# Altium schematic sheet wch554e.SchDoc
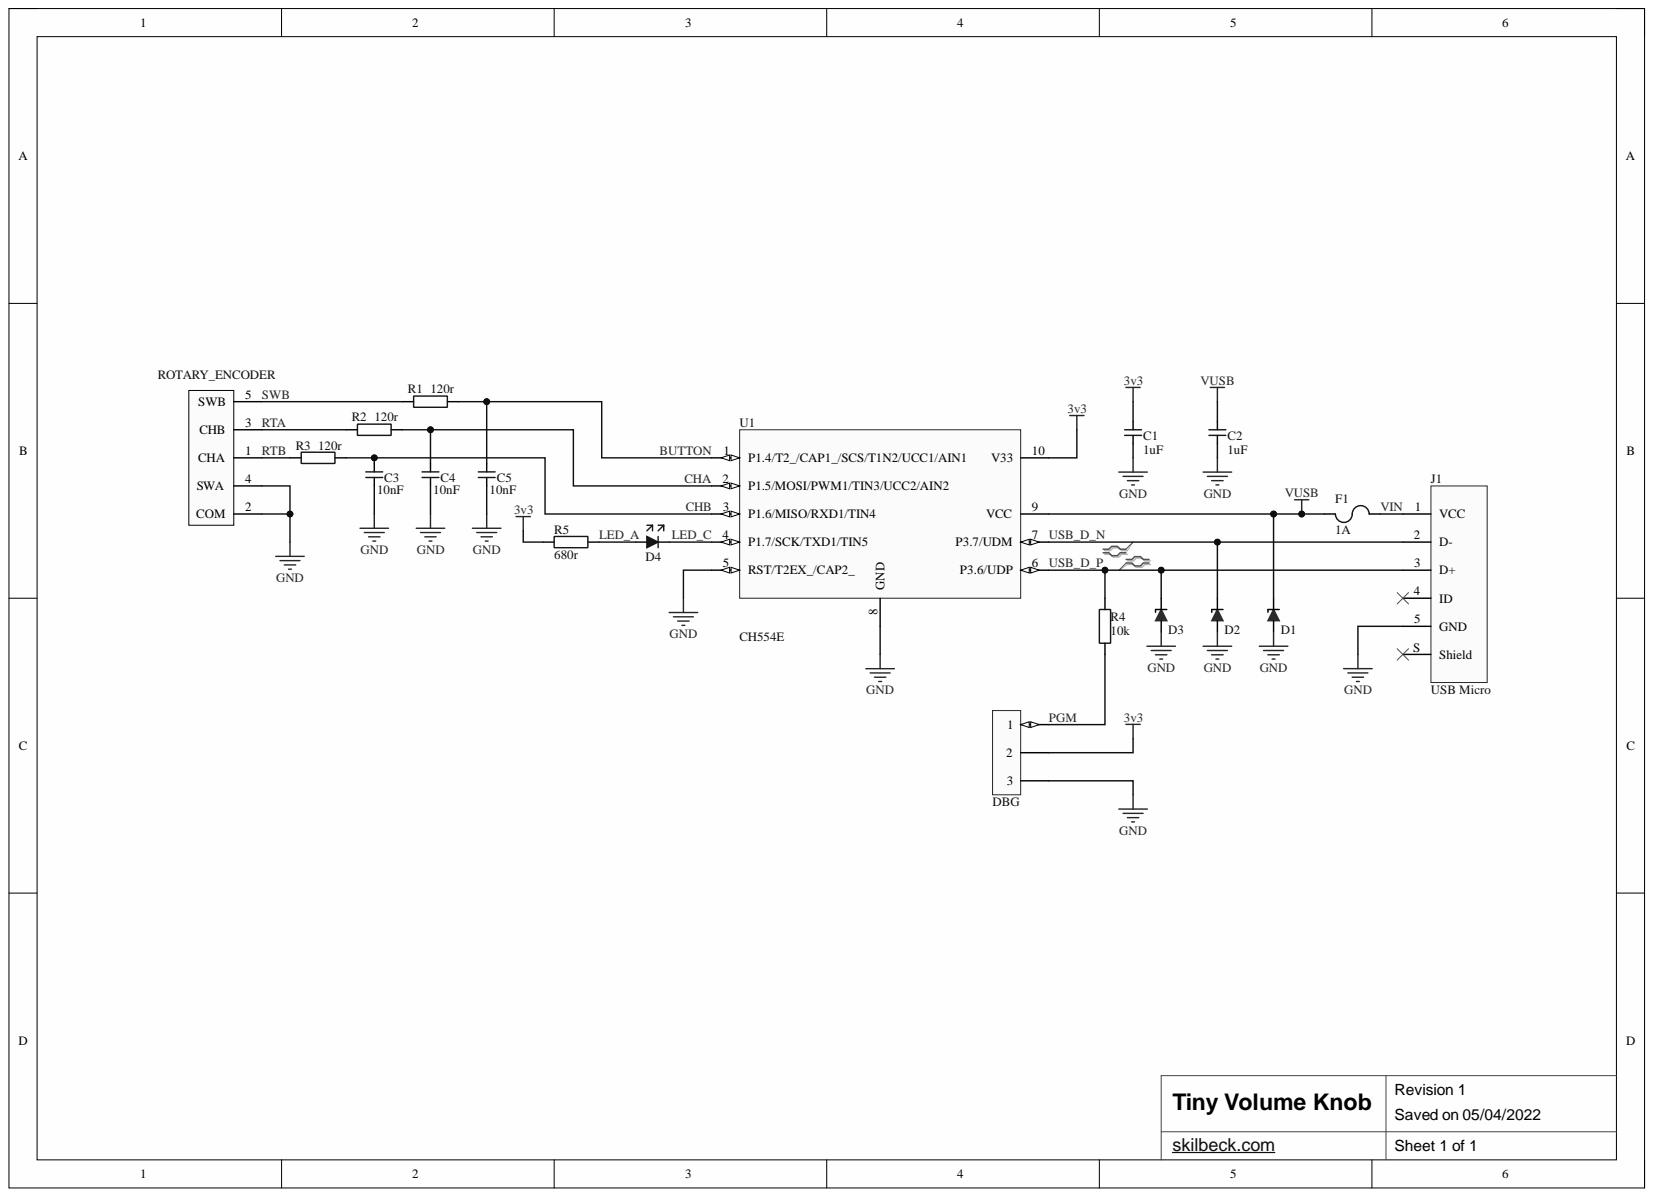
[diagram p0: wch554e.SchDoc]

## Parts
C1  1uF  [MLCC_0603]
C2  1uF  [MLCC_0603]
C3  10nF  [MLCC_0603]
C4  10nF  [MLCC_0603]
C5  10nF  [MLCC_0603]
D1  TVS_DIODE  [TVS_DIODE]  (value not drawn)
D2  TVS_DIODE  [TVS_DIODE]  (value not drawn)
D3  TVS_DIODE  [TVS_DIODE]  (value not drawn)
D4  =Value  [SMT_LED_0603]
DBG  CON4  [CON4]  (value not drawn)  (no BOM)
F1  1A  [FUSE_0805]
J1  USB Micro  [USB MICRO B]
R1  120r  [SMT_RES_0603]
R2  120r  [SMT_RES_0603]
R3  120r  [SMT_RES_0603]
R4  10k  [SMT_RES_0603]
R5  680r  [SMT_RES_0603]
ROTARY_ENCODER  ROTARY_ENCODER  [ENCODER]  (no BOM)
U1  CH554E  [MSOP10]

## Nets
3v3: C1.2 DBG.2 R5.1 U1.10(V33)
GND: C1.1 C2.1 C3.1 C4.1 C5.1 D1.2 D2.2 D3.2 DBG.3 J1.5(GND) ROTARY_ENCODER.2(COM) ROTARY_ENCODER.4(SWA) U1.5(RST/T2EX_/CAP2_) U1.8(GND)
VUSB: C2.2 D1.1 F1.2 U1.9(VCC)
BUTTON: C5.2 R1.2 U1.1(P1.4/T2_/CAP1_/SCS/T1N2/UCC1/AIN1)
CHA: C4.2 R2.2 U1.2(P1.5/MOSI/PWM1/TIN3/UCC2/AIN2)
CHB: C3.2 R3.2 U1.3(P1.6/MISO/RXD1/TIN4)
LED_A: D4.1 R5.2
LED_C: D4.2 U1.4(P1.7/SCK/TXD1/TIN5)
PGM: DBG.1 R4.1
RTA: R2.1 ROTARY_ENCODER.3(CHB)
RTB: R3.1 ROTARY_ENCODER.1(CHA)
SWB: R1.1 ROTARY_ENCODER.5(SWB)
USB_D_N: D2.1 J1.2(D-) U1.7(P3.7/UDM)
USB_D_P: D3.1 J1.3(D+) R4.2 U1.6(P3.6/UDP)
VIN: F1.1 J1.1(VCC)

Not connected: J1 4(ID) S(Shield)

## Library data of the parts
C1-C5 = CAP_CERAMIC: MLCC; Component Kind: Standard; Library Name: wch554e.SchLib
  C1 C2: Supplier Part No. 1: C105524; Value: 1uF
  C3-C5: Supplier Part No. 1: C519509; Value: 10nF
D1-D3 = TVS_DIODE: TVS Diode; Component Kind: Standard; Supplier Part No. 1: C384848; Library Name: wch554e.SchLib
D4 = LED: Component Kind: Standard; Description: LED; Supplier Part No. 1: C434424; Library Name: wch554e.SchLib
DBG = CON4: Debug header; Library Name: wch554e.SchLib
F1 = FUSE: Component Kind: Standard; Description: FUSE; Supplier Part No. 1: C70061; Value: 1A; Library Name: wch554e.SchLib
J1 = USB_SOCKET: USB Receptacle; Component Kind: Standard; Supplier Part No. 1: C397452; Library Name: wch554e.SchLib
R1-R5 = RESISTOR: Component Kind: Standard; Description: Resistor; Library Name: wch554e.SchLib
  R1-R3: Supplier Part No. 1: C22787; Value: 120r
  R4: Supplier Part No. 1: C25804; Value: 10k
  R5: Supplier Part No. 1: C23228; Value: 680r
ROTARY_ENCODER = ROTARY_ENCODER: Rotary Encoder; Library Name: wch554e.SchLib
U1 = CH554E: Component Kind: Standard; Description: CH554E; Supplier Part No. 1: C111293; Library Name: wch554e.SchLib
